# Altium schematic sheet STM32H563RI_CORE&LCD&EXPAND_IO.SchDoc
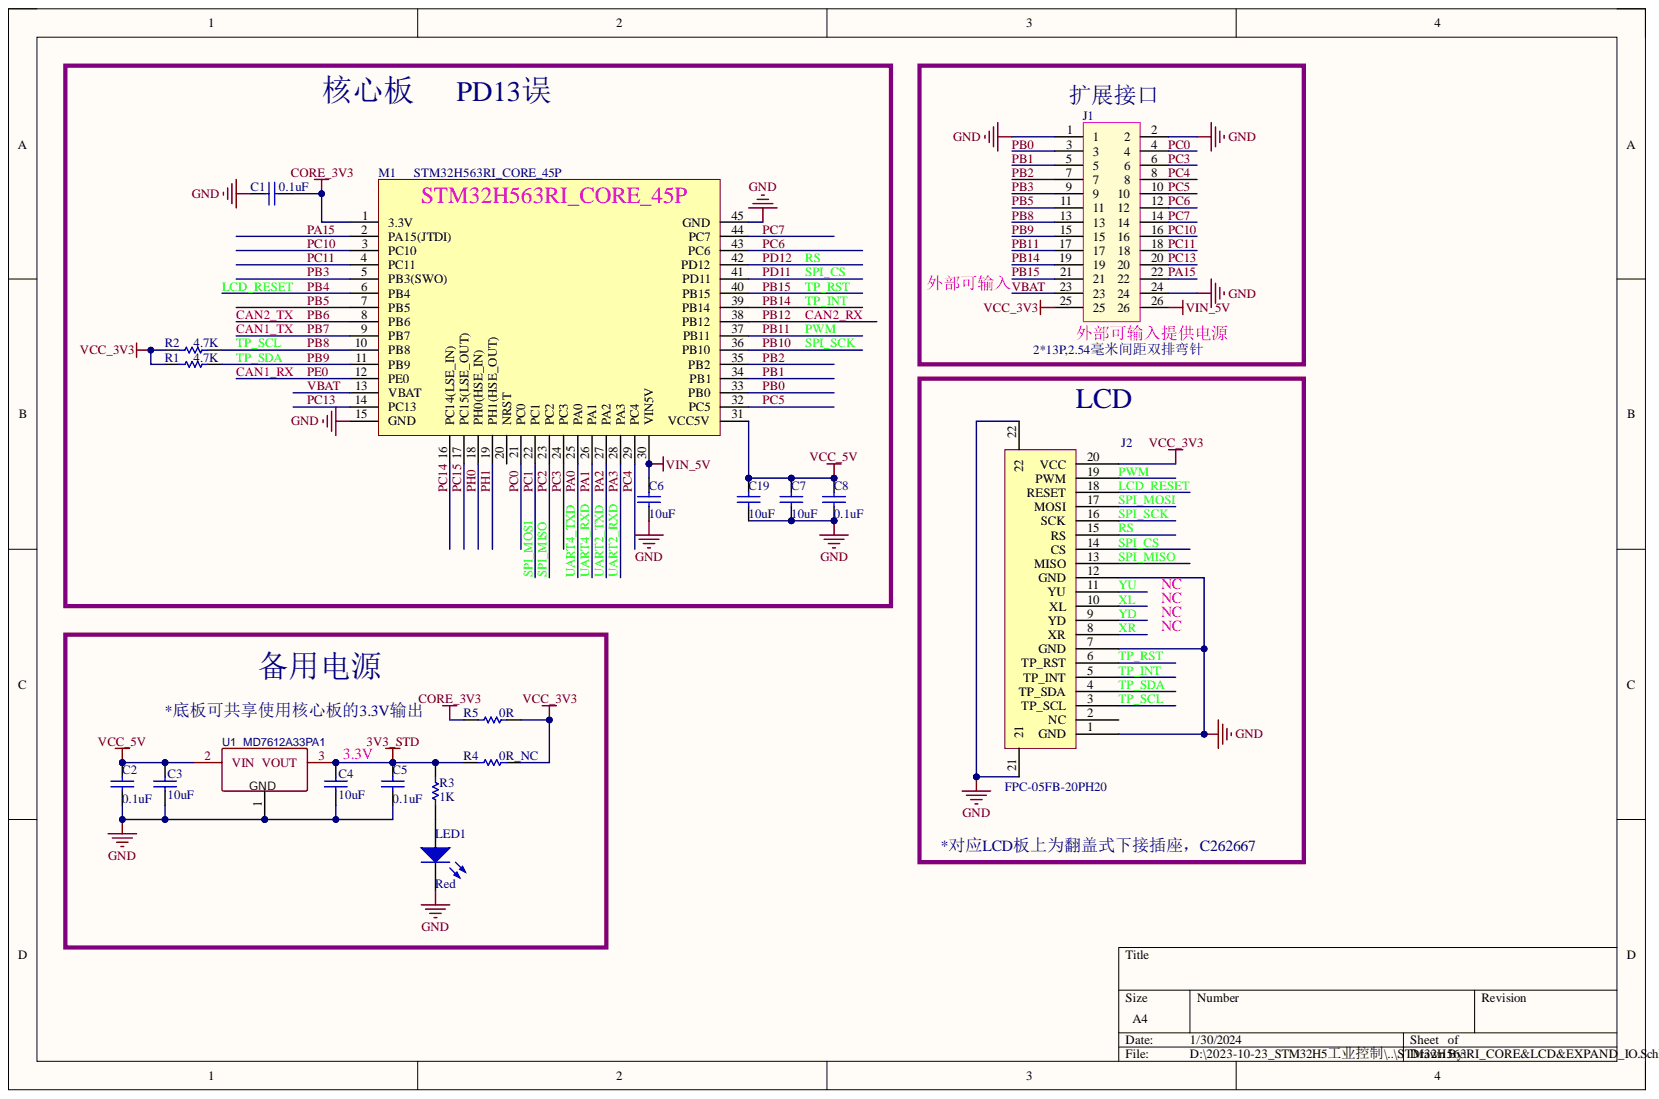
[diagram p0: STM32H563RI_CORE&LCD&EXPAND_IO.SchDoc]

## Parts
C1  0.1uF  [0402]
C2  0.1uF  [0402]
C3  10uF  [0402]
C4  10uF  [0402]
C5  0.1uF  [0402]
C6  10uF  [0402]
C7  10uF  [0402]
C8  0.1uF  [0402]
C19  10uF  [0402]
J1  2*13P,2.54毫米间距双排弯针  [HDR-TH_26P-P2.54-H-M-R2-C13-S2.54]
J2  FPC-05FB-20PH20  [FPC-SMD_20P-P0.50_FPC-05FB-20PH20-MIRROR]
LED1  Red  [LED0402]
M1  STM32H563RI_CORE_45P  [STM32H563RI_CORE_BOARD]
R1  4.7K  [0402]
R2  4.7K  [0402]
R3  1K  [0402]
R4  0R_NC  [0603]
R5  0R  [0603]
U1  MD7612A33PA1  [SOT-89-3_L4.5-W2.5-P1.50-LS4.2-BR]

## Nets
3V3_STD: C4.2 C5.1 R3.2 R4.2 U1.3
CORE_3V3: C1.1 M1.1(3.3V) R5.2
GND: C1.2 C2.2 C3.1 C4.1 C5.2 C6.1 C7.1 C8.1 C19.1 J1.1 J1.2 J1.24 J2.1(GND) J2.7(GND) J2.12(GND) J2.21 J2.22 LED1.2 M1.15(GND) M1.45(GND) U1.1
VCC_3V3: J1.25 J2.20(VCC) R1.1 R2.1 R4.1 R5.1
VCC_5V: C2.1 C3.2 C7.2 C8.2 C19.2 M1.31(VCC5V) U1.2
VIN_5V: C6.2 J1.26 M1.30(VIN5V)
CAN1_RX: M1.12(PE0)
CAN1_TX: M1.9(PB7)
CAN2_RX: M1.38(PB12)
CAN2_TX: M1.8(PB6)
LCD_RESET: J2.18(RESET) M1.6(PB4)
PA0: M1.25(PA0)
PA1: M1.26(PA1)
PA2: M1.27(PA2)
PA3: M1.28(PA3)
PA15: J1.22 M1.2(PA15(JTDI))
PB0: J1.3 M1.33(PB0)
PB1: J1.5 M1.34(PB1)
PB2: J1.7 M1.35(PB2)
PB3: J1.9 M1.5(PB3(SWO))
PB5: J1.11 M1.7(PB5)
PB8: J1.13 J2.3(TP_SCL) M1.10(PB8) R2.2
PB9: J1.15 J2.4(TP_SDA) M1.11(PB9) R1.2
PB10: J2.16(SCK) M1.36(PB10)
PB11: J1.17 J2.19(PWM) M1.37(PB11)
PB14: J1.19 J2.5(TP_INT) M1.39(PB14)
PB15: J1.21 J2.6(TP_RST) M1.40(PB15)
PC0: J1.4 M1.21(PC0)
PC1: J2.17(MOSI) M1.22(PC1)
PC2: J2.13(MISO) M1.23(PC2)
PC3: J1.6 M1.24(PC3)
PC4: J1.8 M1.29(PC4)
PC5: J1.10 M1.32(PC5)
PC6: J1.12 M1.43(PC6)
PC7: J1.14 M1.44(PC7)
PC10: J1.16 M1.3(PC10)
PC11: J1.18 M1.4(PC11)
PC13: J1.20 M1.14(PC13)
PC14: M1.16(PC14(LSE_IN))
PC15: M1.17(PC15(LSE_OUT))
PD11: J2.14(CS) M1.41(PD11)
PD12: J2.15(RS) M1.42(PD12)
PH0: M1.18(PH0(HSE_IN))
PH1: M1.19(PH1(HSE_OUT))
VBAT: J1.23 M1.13(VBAT)
XL: J2.10(XL)
XR: J2.8(XR)
YD: J2.9(YD)
YU: J2.11(YU)
NetLED1_1: LED1.1 R3.1

Not connected: J2 2(NC); M1 20(NRST)

## Library data of the parts
C1 C2 C5-C8 C19 = Cap: Capacitor; PartNumber: CAP100NF; Component Kind: Standard; LatestRevisionDate: 17-Jul-2002; LatestRevisionNote: Re-released for DXP Platform.; Library Name: Miscellaneous Devices.IntLib; PackageReference: 0402; Published: 8-Jun-2000; Signal Integrity: Cap; Simulation: CAP; Publisher: Altium Limited
  C1 C2 C5 C8: Value: 0.1uF
  C6 C7 C19: Value: 10uF
C3 C4 = Cap: Component Kind: Standard; Value: 10uF; Library Name: STLINK.SCHLIB
J1 = IDC26: Component Kind: Standard; JLC NO: C2894993; Library Name: HM2.SchLib; Value: 2*13P,2.54毫米间距双排弯针
J2 = FPC_20P: Component Kind: Standard; JLC NO: C2856830; Library Name: RA6M5.SchLib; VALUE: FPC-05FB-20PH20
LED1 = LED2: Typical RED, GREEN, YELLOW, AMBER GaAs LED; Component Kind: Standard; LatestRevisionDate: 17-Jul-2002; LatestRevisionNote: Re-released for DXP Platform.; Library Name: Miscellaneous Devices.IntLib; PackageDescription: SM LED; 2 Flat Leads; PackageReference: 3.2X1.6X1.1; PackageVersion: Feb-2002; Published: 8-Jun-2000; Simulation: LED2; Value: Red; Publisher: Altium Limited
R1-R5 = Res1: Resistor; PartNumber: RES2.2K; Component Kind: Standard; LatestRevisionDate: 17-Jul-2002; LatestRevisionNote: Re-released for DXP Platform.; Library Name: Miscellaneous Devices.IntLib; PackageReference: 0402; Published: 8-Jun-2000; Signal Integrity: Res; Simulation: RESISTOR; Publisher: Altium Limited
  R1 R2: Value: 4.7K
  R3: Value: 1K
  R4: Value: 0R_NC
  R5: Value: 0R
U1 = MD7612A33PA1: Contributor: lcsc; BOM_Manufacturer Part: MD7612A33PA1; BOM_Supplier: LCSC; BOM_Supplier Part: C2978350; Component Kind: Standard; Library Name: HM2.SchLib; link: https://atta.szlcsc.com/upload/public/pdf/source/20220309/DA883751BDA22ECB80106D5B2749DB60.pdf; spicePre: U; spiceSymbolName: MD7612A33PA1
M1 = STM32H563RI_CORE_45P: Component Kind: Standard; Library Name: HM2.SchLib
